# Altium schematic sheet eACU.SchDoc
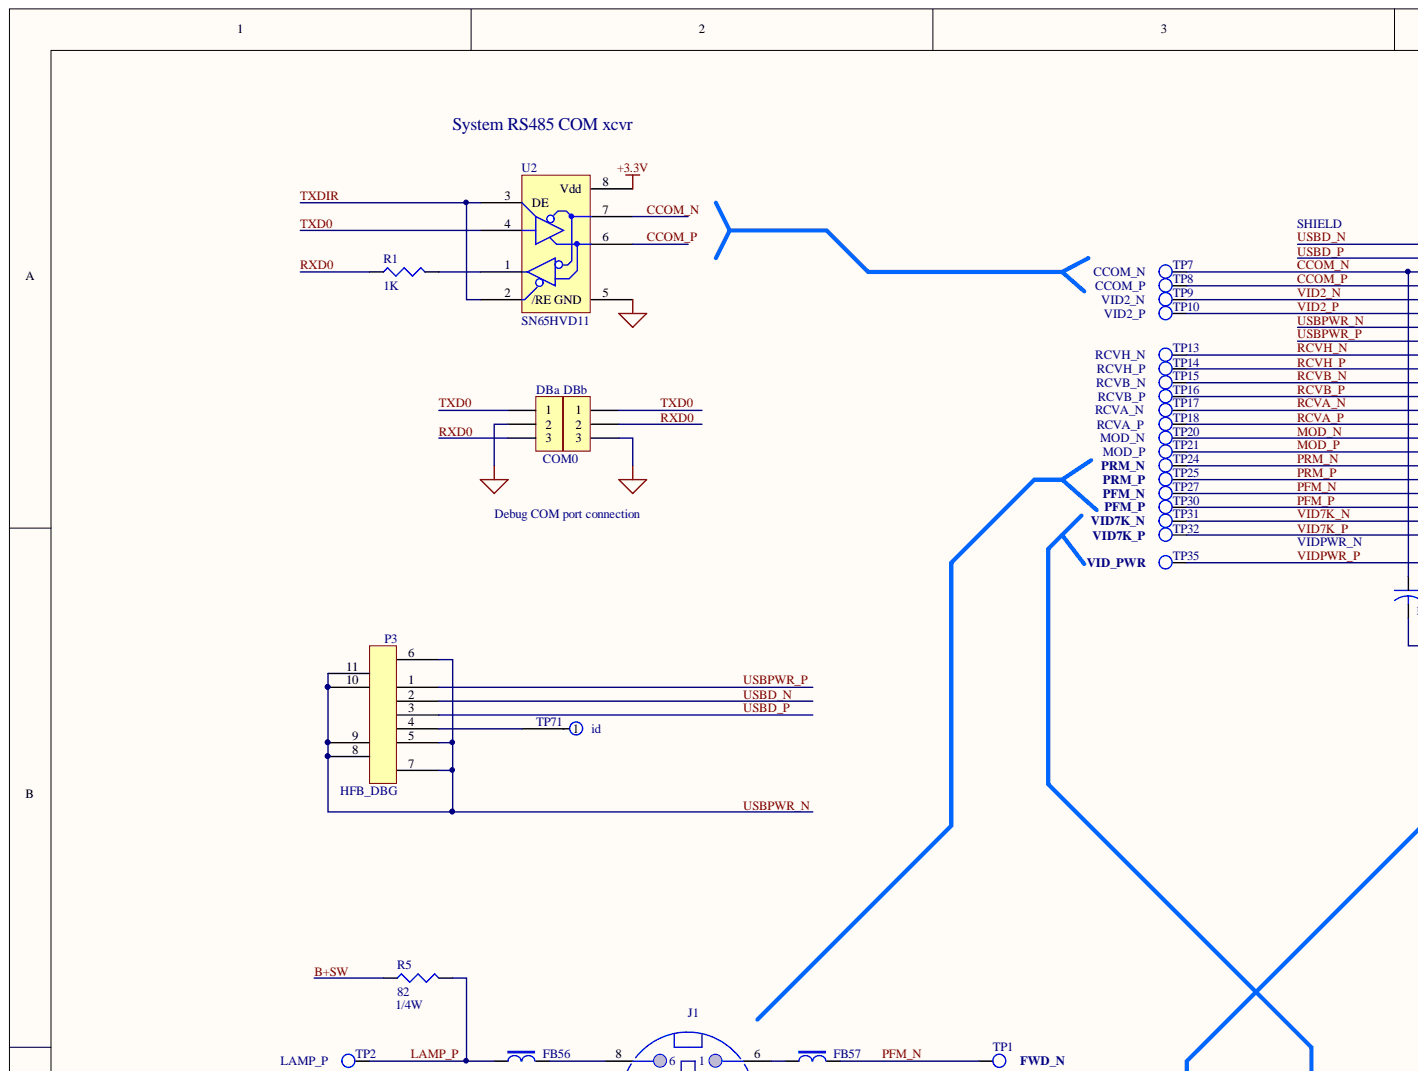
[diagram p0: eACU.SchDoc, top left]
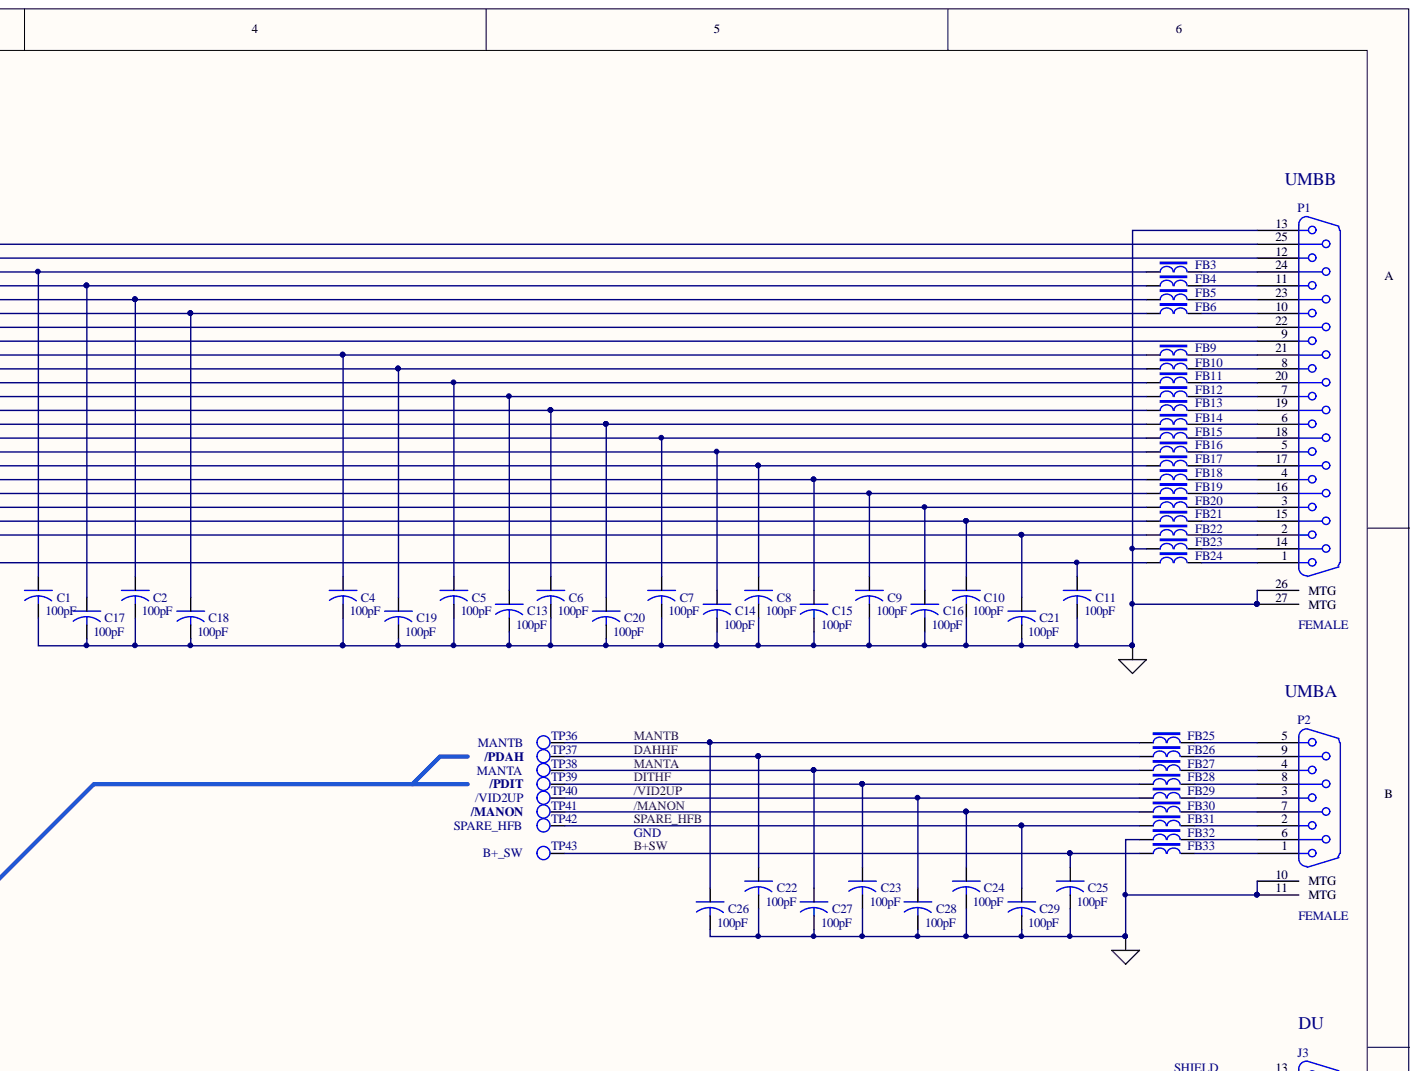
[diagram p1: eACU.SchDoc, top right]
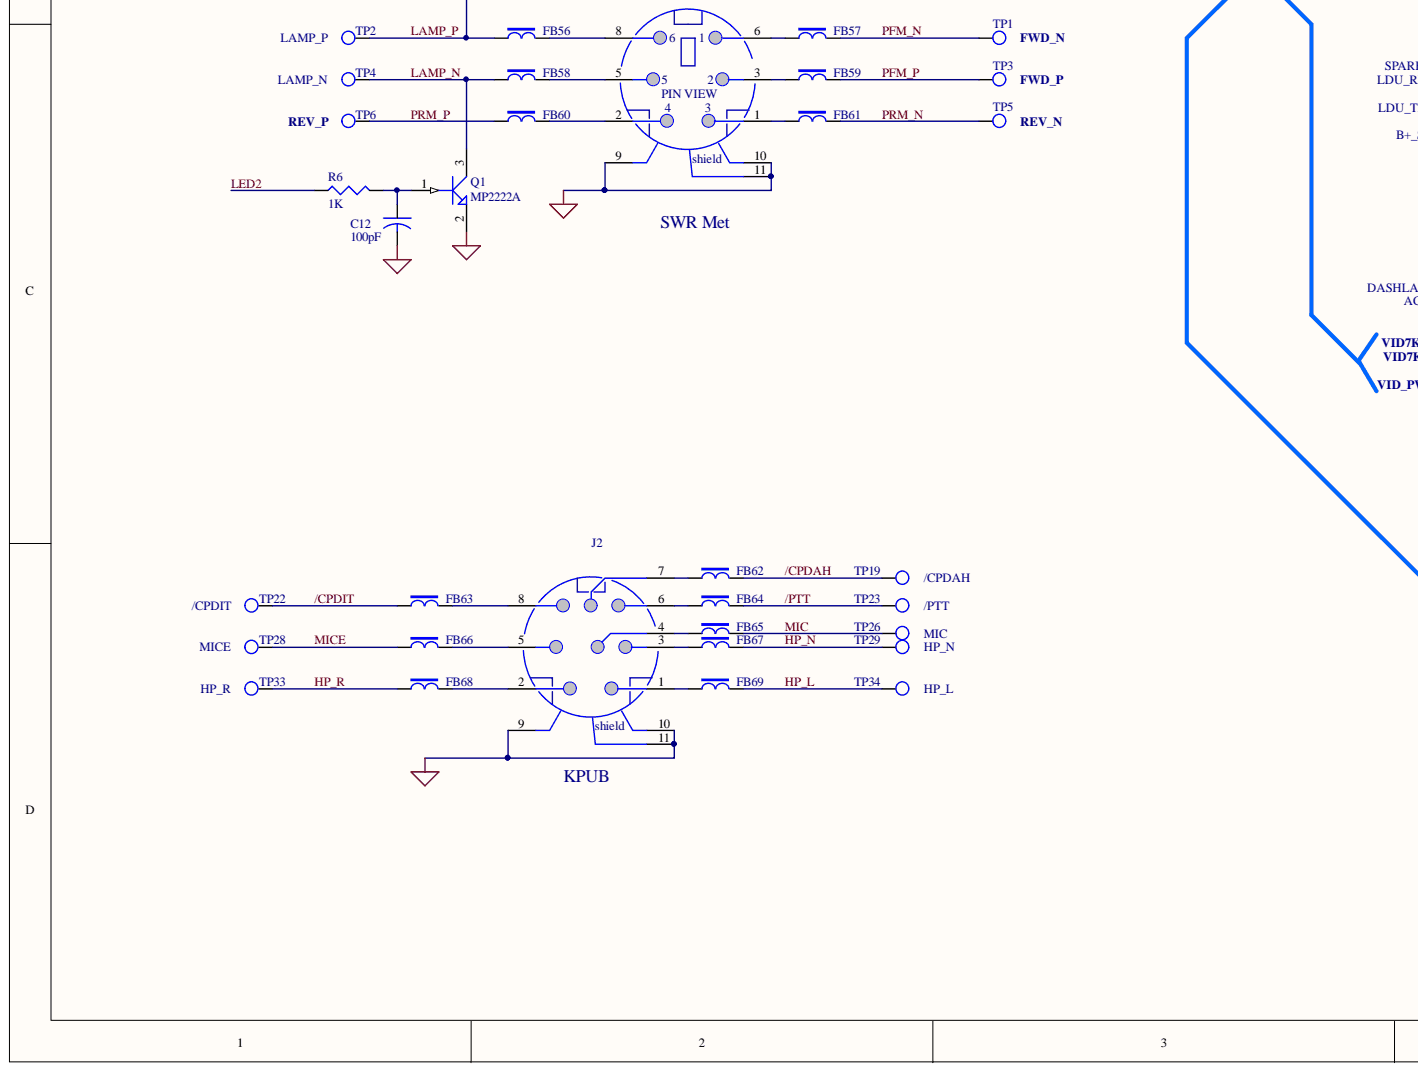
[diagram p2: eACU.SchDoc, bottom left]
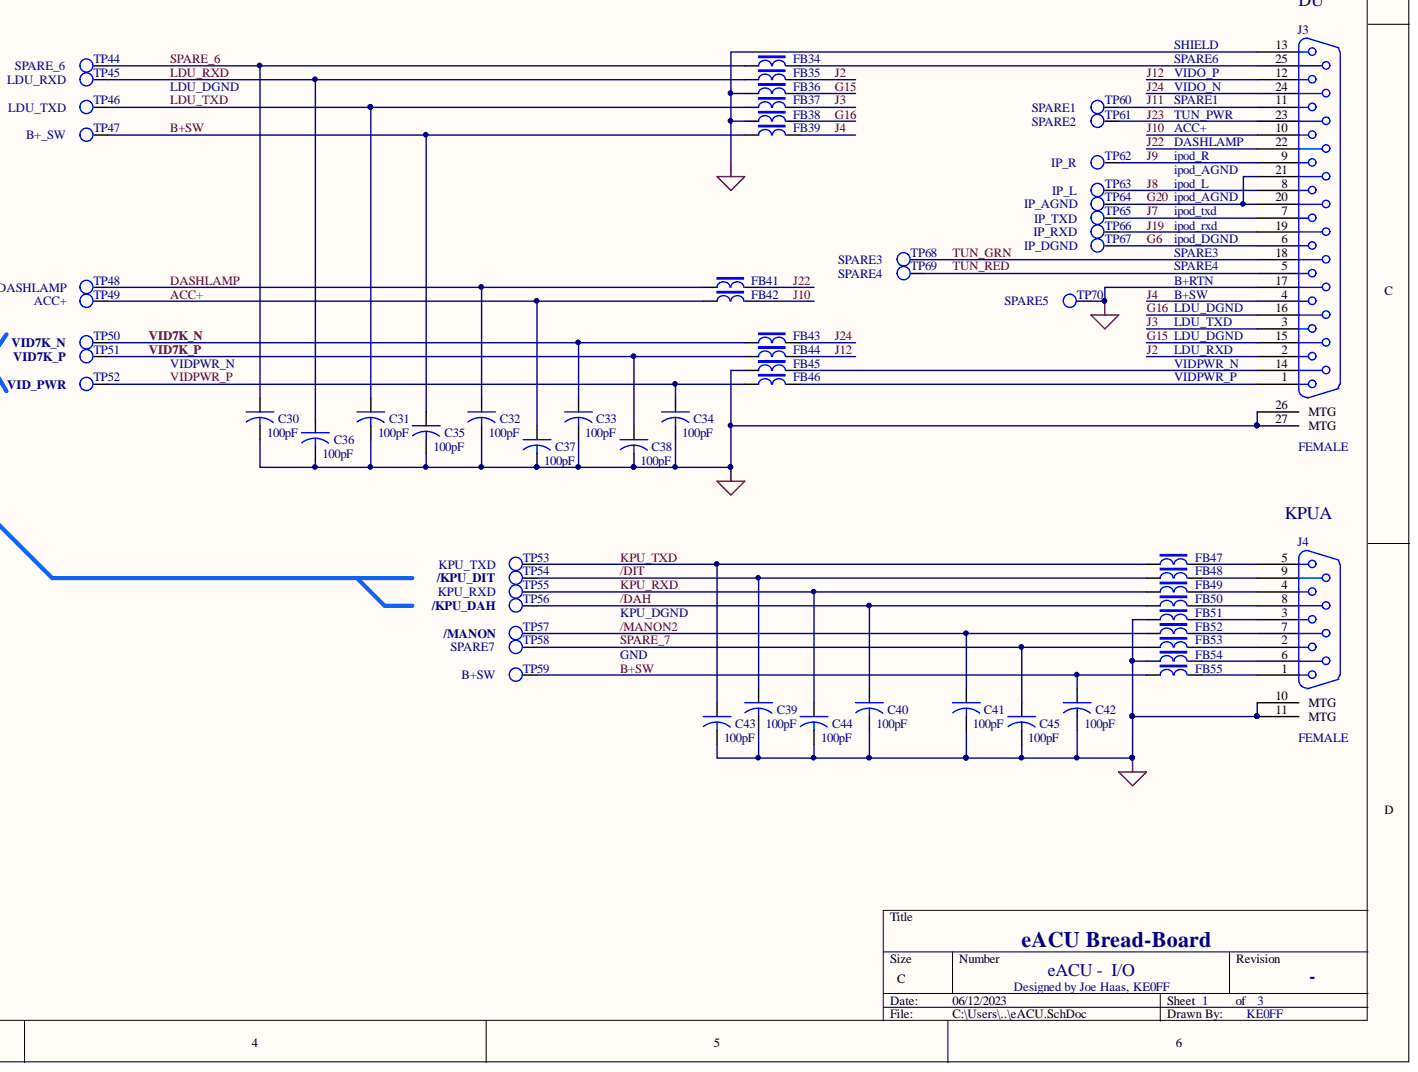
[diagram p3: eACU.SchDoc, bottom right]

## Parts
C1  100pF  [CAP_0603]
C2  100pF  [CAP_0603]
C4  100pF  [CAP_0603]
C5  100pF  [CAP_0603]
C6  100pF  [CAP_0603]
C7  100pF  [CAP_0603]
C8  100pF  [CAP_0603]
C9  100pF  [CAP_0603]
C10  100pF  [CAP_0603]
C11  100pF  [CAP_0603]
C12  100pF  [0402]
C13  100pF  [CAP_0603]
C14  100pF  [CAP_0603]
C15  100pF  [CAP_0603]
C16  100pF  [CAP_0603]
C17  100pF  [CAP_0603]
C18  100pF  [CAP_0603]
C19  100pF  [CAP_0603]
C20  100pF  [CAP_0603]
C21  100pF  [CAP_0603]
C22  100pF  [CAP_0603]
C23  100pF  [CAP_0603]
C24  100pF  [CAP_0603]
C25  100pF  [CAP_0603]
C26  100pF  [CAP_0603]
C27  100pF  [CAP_0603]
C28  100pF  [CAP_0603]
C29  100pF  [CAP_0603]
C30  100pF  [CAP_0603]
C31  100pF  [CAP_0603]
C32  100pF  [CAP_0603]
C33  100pF  [CAP_0603]
C34  100pF  [CAP_0603]
C35  100pF  [CAP_0603]
C36  100pF  [CAP_0603]
C37  100pF  [CAP_0603]
C38  100pF  [CAP_0603]
C39  100pF  [CAP_0603]
C40  100pF  [CAP_0603]
C41  100pF  [CAP_0603]
C42  100pF  [CAP_0603]
C43  100pF  [CAP_0603]
C44  100pF  [CAP_0603]
C45  100pF  [CAP_0603]
DBa  COM0  [HDR1X3]
DBb  CON3  [HDR1X3]  (value not drawn)
FB3  1K  [FB_0603]  (value not drawn)
FB4  1K  [FB_0603]  (value not drawn)
FB5  1K  [FB_0603]  (value not drawn)
FB6  1K  [FB_0603]  (value not drawn)
FB9  1K  [FB_0603]  (value not drawn)
FB10  1K  [FB_0603]  (value not drawn)
FB11  1K  [FB_0603]  (value not drawn)
FB12  1K  [FB_0603]  (value not drawn)
FB13  1K  [FB_0603]  (value not drawn)
FB14  1K  [FB_0603]  (value not drawn)
FB15  1K  [FB_0603]  (value not drawn)
FB16  1K  [FB_0603]  (value not drawn)
FB17  1K  [FB_0603]  (value not drawn)
FB18  1K  [FB_0603]  (value not drawn)
FB19  1K  [FB_0603]  (value not drawn)
FB20  1K  [FB_0603]  (value not drawn)
FB21  1K  [FB_0603]  (value not drawn)
FB22  1K  [FB_0603]  (value not drawn)
FB23  1K  [FB_0603]  (value not drawn)
FB24  1K  [FB_0603]  (value not drawn)
FB25  1K  [FB_0603]  (value not drawn)
FB26  1K  [FB_0603]  (value not drawn)
FB27  1K  [FB_0603]  (value not drawn)
FB28  1K  [FB_0603]  (value not drawn)
FB29  1K  [FB_0603]  (value not drawn)
FB30  1K  [FB_0603]  (value not drawn)
FB31  1K  [FB_0603]  (value not drawn)
FB32  1K  [FB_0603]  (value not drawn)
FB33  1K  [FB_0603]  (value not drawn)
FB34  1K  [FB_0603]  (value not drawn)
FB35  1K  [FB_0603]  (value not drawn)
FB36  1K  [FB_0603]  (value not drawn)
FB37  1K  [FB_0603]  (value not drawn)
FB38  1K  [FB_0603]  (value not drawn)
FB39  1K  [FB_0603]  (value not drawn)
FB41  1K  [FB_0603]  (value not drawn)
FB42  1K  [FB_0603]  (value not drawn)
FB43  1K  [FB_0603]  (value not drawn)
FB44  1K  [FB_0603]  (value not drawn)
FB45  1K  [FB_0603]  (value not drawn)
FB46  1K  [FB_0603]  (value not drawn)
FB47  1K  [FB_0603]  (value not drawn)
FB48  1K  [FB_0603]  (value not drawn)
FB49  1K  [FB_0603]  (value not drawn)
FB50  1K  [FB_0603]  (value not drawn)
FB51  1K  [FB_0603]  (value not drawn)
FB52  1K  [FB_0603]  (value not drawn)
FB53  1K  [FB_0603]  (value not drawn)
FB54  1K  [FB_0603]  (value not drawn)
FB55  1K  [FB_0603]  (value not drawn)
FB56  1K  [FB_0603]  (value not drawn)
FB57  1K  [FB_0603]  (value not drawn)
FB58  1K  [FB_0603]  (value not drawn)
FB59  1K  [FB_0603]  (value not drawn)
FB60  1K  [FB_0603]  (value not drawn)
FB61  1K  [FB_0603]  (value not drawn)
FB62  1K  [FB_0603]  (value not drawn)
FB63  1K  [FB_0603]  (value not drawn)
FB64  1K  [FB_0603]  (value not drawn)
FB65  1K  [FB_0603]  (value not drawn)
FB66  1K  [FB_0603]  (value not drawn)
FB67  1K  [FB_0603]  (value not drawn)
FB68  1K  [FB_0603]  (value not drawn)
FB69  1K  [FB_0603]  (value not drawn)
J1  SWR Met  [MINI-DIN6]
J2  KPUB  [MINI-DIN8]
J3  DU  [DB25FV]
J4  KPUA  [DB9FV]
P1  UMBB  [DB25FV]
P2  UMBA  [DB9FV]
P3  HFB_DBG  [USB_MICRO_SMD]
Q1  MP2222A  [SOT23-A]
R1  1K  [0402]
R5  82  Text Field1: 1/4W  [0402]
R6  1K  [0402]
TP1  FWD_N  [TP-HOLE-28]  (no BOM)
TP2  LAMP_P  [TP-HOLE-28]  (no BOM)
TP3  FWD_P  [TP-HOLE-28]  (no BOM)
TP4  LAMP_N  [TP-HOLE-28]  (no BOM)
TP5  REV_N  [TP-HOLE-28]  (no BOM)
TP6  REV_P  [TP-HOLE-28]  (no BOM)
TP7  CCOM_N  [TP-HOLE-28]  (no BOM)
TP8  CCOM_P  [TP-HOLE-28]  (no BOM)
TP9  VID2_N  [TP-HOLE-28]  (no BOM)
TP10  VID2_P  [TP-HOLE-28]  (no BOM)
TP13  RCVH_N  [TP-HOLE-28]  (no BOM)
TP14  RCVH_P  [TP-HOLE-28]  (no BOM)
TP15  RCVB_N  [TP-HOLE-28]  (no BOM)
TP16  RCVB_P  [TP-HOLE-28]  (no BOM)
TP17  RCVA_N  [TP-HOLE-28]  (no BOM)
TP18  RCVA_P  [TP-HOLE-28]  (no BOM)
TP19  /CPDAH  [TP-HOLE-28]  (no BOM)
TP20  MOD_N  [TP-HOLE-28]  (no BOM)
TP21  MOD_P  [TP-HOLE-28]  (no BOM)
TP22  /CPDIT  [TP-HOLE-28]  (no BOM)
TP23  /PTT  [TP-HOLE-28]  (no BOM)
TP24  PRM_N  [TP-HOLE-28]  (no BOM)
TP25  PRM_P  [TP-HOLE-28]  (no BOM)
TP26  MIC  [TP-HOLE-28]  (no BOM)
TP27  PFM_N  [TP-HOLE-28]  (no BOM)
TP28  MICE  [TP-HOLE-28]  (no BOM)
TP29  HP_N  [TP-HOLE-28]  (no BOM)
TP30  PFM_P  [TP-HOLE-28]  (no BOM)
TP31  VID7K_N  [TP-HOLE-28]  (no BOM)
TP32  VID7K_P  [TP-HOLE-28]  (no BOM)
TP33  HP_R  [TP-HOLE-28]  (no BOM)
TP34  HP_L  [TP-HOLE-28]  (no BOM)
TP35  VID_PWR  [TP-HOLE-28]  (no BOM)
TP36  MANTB  [TP-HOLE-28]  (no BOM)
TP37  /PDAH  [TP-HOLE-28]  (no BOM)
TP38  MANTA  [TP-HOLE-28]  (no BOM)
TP39  /PDIT  [TP-HOLE-28]  (no BOM)
TP40  /VID2UP  [TP-HOLE-28]  (no BOM)
TP41  /MANON  [TP-HOLE-28]  (no BOM)
TP42  SPARE_HFB  [TP-HOLE-28]  (no BOM)
TP43  B+_SW  [TP-HOLE-28]  (no BOM)
TP44  SPARE_6  [TP-HOLE-28]  (no BOM)
TP45  LDU_RXD  [TP-HOLE-28]  (no BOM)
TP46  LDU_TXD  [TP-HOLE-28]  (no BOM)
TP47  B+_SW  [TP-HOLE-28]  (no BOM)
TP48  DASHLAMP  [TP-HOLE-28]  (no BOM)
TP49  ACC+  [TP-HOLE-28]  (no BOM)
TP50  VID7K_N  [TP-HOLE-28]  (no BOM)
TP51  VID7K_P  [TP-HOLE-28]  (no BOM)
TP52  VID_PWR  [TP-HOLE-28]  (no BOM)
TP53  KPU_TXD  [TP-HOLE-28]  (no BOM)
TP54  /KPU_DIT  [TP-HOLE-28]  (no BOM)
TP55  KPU_RXD  [TP-HOLE-28]  (no BOM)
TP56  /KPU_DAH  [TP-HOLE-28]  (no BOM)
TP57  /MANON  [TP-HOLE-28]  (no BOM)
TP58  SPARE7  [TP-HOLE-28]  (no BOM)
TP59  B+SW  [TP-HOLE-28]  (no BOM)
TP60  SPARE1  [TP-HOLE-28]  (no BOM)
TP61  SPARE2  [TP-HOLE-28]  (no BOM)
TP62  IP_R  [TP-HOLE-28]  (no BOM)
TP63  IP_L  [TP-HOLE-28]  (no BOM)
TP64  IP_AGND  [TP-HOLE-28]  (no BOM)
TP65  IP_TXD  [TP-HOLE-28]  (no BOM)
TP66  IP_RXD  [TP-HOLE-28]  (no BOM)
TP67  IP_DGND  [TP-HOLE-28]  (no BOM)
TP68  SPARE3  [TP-HOLE-28]  (no BOM)
TP69  SPARE4  [TP-HOLE-28]  (no BOM)
TP70  SPARE5  [TP-HOLE-28]  (no BOM)
TP71  id  [TP]
U2  SN65HVD11  [SOIC8]

## Nets
+3.3V: U2.8(Vdd)
GND: C1.2 C2.2 C4.2 C5.2 C6.2 C7.2 C8.2 C9.2 C10.2 C11.2 C12.2 C13.2 C14.2 C15.2 C16.2 C17.2 C18.2 C19.2 C20.2 C21.2 C22.2 C23.2 C24.2 C25.2 C26.2 C27.2 C28.2 C29.2 C30.2 C31.2 C32.2 C33.2 C34.2 C35.2 C36.2 C37.2 C38.2 C39.2 C40.2 C41.2 C42.2 C43.2 C44.2 C45.2 FB23.1 FB32.1 FB36.1 FB38.1 FB45.1 FB51.1 FB54.1 J1.9 J1.10(shield) J1.11 J2.9 J2.10(shield) J2.11 J3.13 J3.17 J3.26(MTG) J3.27(MTG) J4.10(MTG) J4.11(MTG) P1.13 P1.26(MTG) P1.27(MTG) P2.10(MTG) P2.11(MTG) Q1.2 TP70.1
SGND: DBa.2 DBb.3 U2.5(GND)
/CPDAH: FB62.2 TP19.1
/CPDIT: FB63.1 TP22.1
/DAH: C40.1 FB50.1 TP56.1
/DIT: C39.1 FB48.1 TP54.1
/MANON: C24.1 FB30.1 TP41.1
/MANON2: C41.1 FB52.1 TP57.1
/PTT: FB64.2 TP23.1
/VID2UP: C28.1 FB29.1 TP40.1
ACC+: C37.1 FB42.1 TP49.1
B+SW: C25.1 C35.1 C42.1 FB33.1 FB39.1 FB55.1 R5.1 TP43.1 TP47.1 TP59.1
CCOM_N: C1.1 FB3.1 TP7.1 U2.7
CCOM_P: C17.1 FB4.1 TP8.1 U2.6
DAHHF: C22.1 FB26.1 TP37.1
DASHLAMP: C32.1 FB41.1 TP48.1
DITHF: C23.1 FB28.1 TP39.1
G6: J3.6 TP67.1
G15: FB36.2 J3.15
G16: FB38.2 J3.16
G20: J3.20 J3.21 TP64.1
HP_L: FB69.2 TP34.1
HP_N: FB67.2 TP29.1
HP_R: FB68.1 TP33.1
J2: FB35.2 J3.2
J3: FB37.2 J3.3
J4: FB39.2 J3.4
J7: J3.7 TP65.1
J8: J3.8 TP63.1
J9: J3.9 TP62.1
J10: FB42.2 J3.10
J11: J3.11 TP60.1
J12: FB44.2 J3.12
J19: J3.19 TP66.1
J22: FB41.2 J3.22
J23: J3.23 TP61.1
J24: FB43.2 J3.24
KPU_RXD: C44.1 FB49.1 TP55.1
KPU_TXD: C43.1 FB47.1 TP53.1
LAMP_N: FB58.1 Q1.3 TP4.1
LAMP_P: FB56.1 R5.2 TP2.1
LDU_RXD: C36.1 FB35.1 TP45.1
LDU_TXD: C31.1 FB37.1 TP46.1
LED2: R6.1
MANTA: C27.1 FB27.1 TP38.1
MANTB: C26.1 FB25.1 TP36.1
MIC: FB65.2 TP26.1
MICE: FB66.1 TP28.1
MOD_N: C7.1 FB15.1 TP20.1
MOD_P: C14.1 FB16.1 TP21.1
PFM_N: C9.1 FB19.1 FB57.2 TP1.1 TP27.1
PFM_P: C16.1 FB20.1 FB59.2 TP3.1 TP30.1
PRM_N: C8.1 FB17.1 FB61.2 TP5.1 TP24.1
PRM_P: C15.1 FB18.1 FB60.1 TP6.1 TP25.1
RCVA_N: C6.1 FB13.1 TP17.1
RCVA_P: C20.1 FB14.1 TP18.1
RCVB_N: C5.1 FB11.1 TP15.1
RCVB_P: C13.1 FB12.1 TP16.1
RCVH_N: C4.1 FB9.1 TP13.1
RCVH_P: C19.1 FB10.1 TP14.1
RXD0: DBa.3 DBb.2 R1.1
SPARE_6: C30.1 FB34.1 TP44.1
SPARE_7: C45.1 FB53.1 TP58.1
SPARE_HFB: C29.1 FB31.1 TP42.1
TUN_GRN: J3.18 TP68.1
TUN_RED: J3.5 TP69.1
TXD0: DBa.1 DBb.1 U2.4
TXDIR: U2.2(/RE) U2.3(DE)
USBD_N: P1.25 P3.2
USBD_P: P1.12 P3.3
USBPWR_N: P1.22 P3.5 P3.6 P3.7 P3.8 P3.9 P3.10 P3.11
USBPWR_P: P1.9 P3.1
VID2_N: C2.1 FB5.1 TP9.1
VID2_P: C18.1 FB6.1 TP10.1
VID7K_N: C10.1 C33.1 FB21.1 FB43.1 TP31.1 TP50.1
VID7K_P: C21.1 C38.1 FB22.1 FB44.1 TP32.1 TP51.1
VIDPWR_P: C11.1 C34.1 FB24.1 FB46.1 TP35.1 TP52.1
NetC12_1: C12.1 Q1.1 R6.2
NetFB3_2: FB3.2 P1.24
NetFB4_2: FB4.2 P1.11
NetFB5_2: FB5.2 P1.23
NetFB6_2: FB6.2 P1.10
NetFB9_2: FB9.2 P1.21
NetFB10_2: FB10.2 P1.8
NetFB11_2: FB11.2 P1.20
NetFB12_2: FB12.2 P1.7
NetFB13_2: FB13.2 P1.19
NetFB14_2: FB14.2 P1.6
NetFB15_2: FB15.2 P1.18
NetFB16_2: FB16.2 P1.5
NetFB17_2: FB17.2 P1.17
NetFB18_2: FB18.2 P1.4
NetFB19_2: FB19.2 P1.16
NetFB20_2: FB20.2 P1.3
NetFB21_2: FB21.2 P1.15
NetFB22_2: FB22.2 P1.2
NetFB23_2: FB23.2 P1.14
NetFB24_2: FB24.2 P1.1
NetFB25_2: FB25.2 P2.5
NetFB26_2: FB26.2 P2.9
NetFB27_2: FB27.2 P2.4
NetFB28_2: FB28.2 P2.8
NetFB29_2: FB29.2 P2.3
NetFB30_2: FB30.2 P2.7
NetFB31_2: FB31.2 P2.2
NetFB32_2: FB32.2 P2.6
NetFB33_2: FB33.2 P2.1
NetFB34_2: FB34.2 J3.25
NetFB45_2: FB45.2 J3.14
NetFB46_2: FB46.2 J3.1
NetFB47_2: FB47.2 J4.5
NetFB48_2: FB48.2 J4.9
NetFB49_2: FB49.2 J4.4
NetFB50_2: FB50.2 J4.8
NetFB51_2: FB51.2 J4.3
NetFB52_2: FB52.2 J4.7
NetFB53_2: FB53.2 J4.2
NetFB54_2: FB54.2 J4.6
NetFB55_2: FB55.2 J4.1
NetFB56_2: FB56.2 J1.8
NetFB57_1: FB57.1 J1.6
NetFB58_2: FB58.2 J1.5
NetFB59_1: FB59.1 J1.3
NetFB60_2: FB60.2 J1.2
NetFB61_1: FB61.1 J1.1
NetFB62_1: FB62.1 J2.7
NetFB63_2: FB63.2 J2.8
NetFB64_1: FB64.1 J2.6
NetFB65_1: FB65.1 J2.4
NetFB66_2: FB66.2 J2.5
NetFB67_1: FB67.1 J2.3
NetFB68_2: FB68.2 J2.2
NetFB69_1: FB69.1 J2.1
NetP3_4: P3.4 TP71.1
NetR1_2: R1.2 U2.1

## Library data of the parts
C1 C2 C4-C11 C13-C45 = CAP 1206 50V: Component Kind: Standard
C12 = CAP 0805: Component Kind: Standard
DBa DBb = CON3: Connector, 3 pin; ALT-MPN: -; Component Kind: Standard; DNP: -; MFR: -; MPN: -; NOTES: -; NOTES2: -; PRI-MPN: -; Library Name: Galaxy SCH-jem-1.08.SchLib
FB3-FB6 FB9-FB39 FB41-FB69 = FB: MFR_1: TDK; MFR_1_P/N: MMZ1608R102ATD25; GPN: P10093; Component Kind: Standard; Library Name: ideck_auto.SCHLIB
J1 = CONN_miniDIN6: MFR_1: Kycon; MFR_1_P/N: KMDGX-6S-BS; Component Kind: Standard; Library Name: acu_extras.SchLib
J2 = CONN_miniDIN8: MFR_1: Kycon; MFR_1_P/N: KMDGX-8S-BS; Component Kind: Standard; Library Name: acu_extras.SchLib
J3 P1 = DB25: Text Field1: 30; Text Field2: 160; Component Kind: Standard; Library Name: acu_extras.SchLib
J4 P2 = DB9: Text Field1: 30; Text Field2: 160; Component Kind: Standard; Library Name: acu_extras.SchLib
P3 = CON_USB-MICRO: CON, DIP, 16 POS; DPN: 47346-1001; Component Kind: Standard; Library Name: MorseHID_revA.SCHLIB
Q1 = NPN1: TR, NPN; ALT-MPN: -; Component Kind: Standard; DNP: -; MFR: -; MPN: -; NOTES: -; NOTES2: -; PRI-MPN: -; Library Name: Galaxy SCH-jem-1.08.SchLib
R1 R6 = R0805: Text Field1: 20; Text Field2: 30; Component Kind: Standard
R5 = R0805: Text Field2: 30; Component Kind: Standard
TP1-TP10 TP13-TP70 = TP_SM: TP, PCB, NOBOM; Library Name: 2102735-1.SchLib
TP71 = TP: Component Kind: Standard; Library Name: MorseHID_revA.SCHLIB
U2 = SN65HVD11: IC, XCVR, RS485; Component Kind: Standard
